# Altium schematic sheet EIT_IO.SchDoc
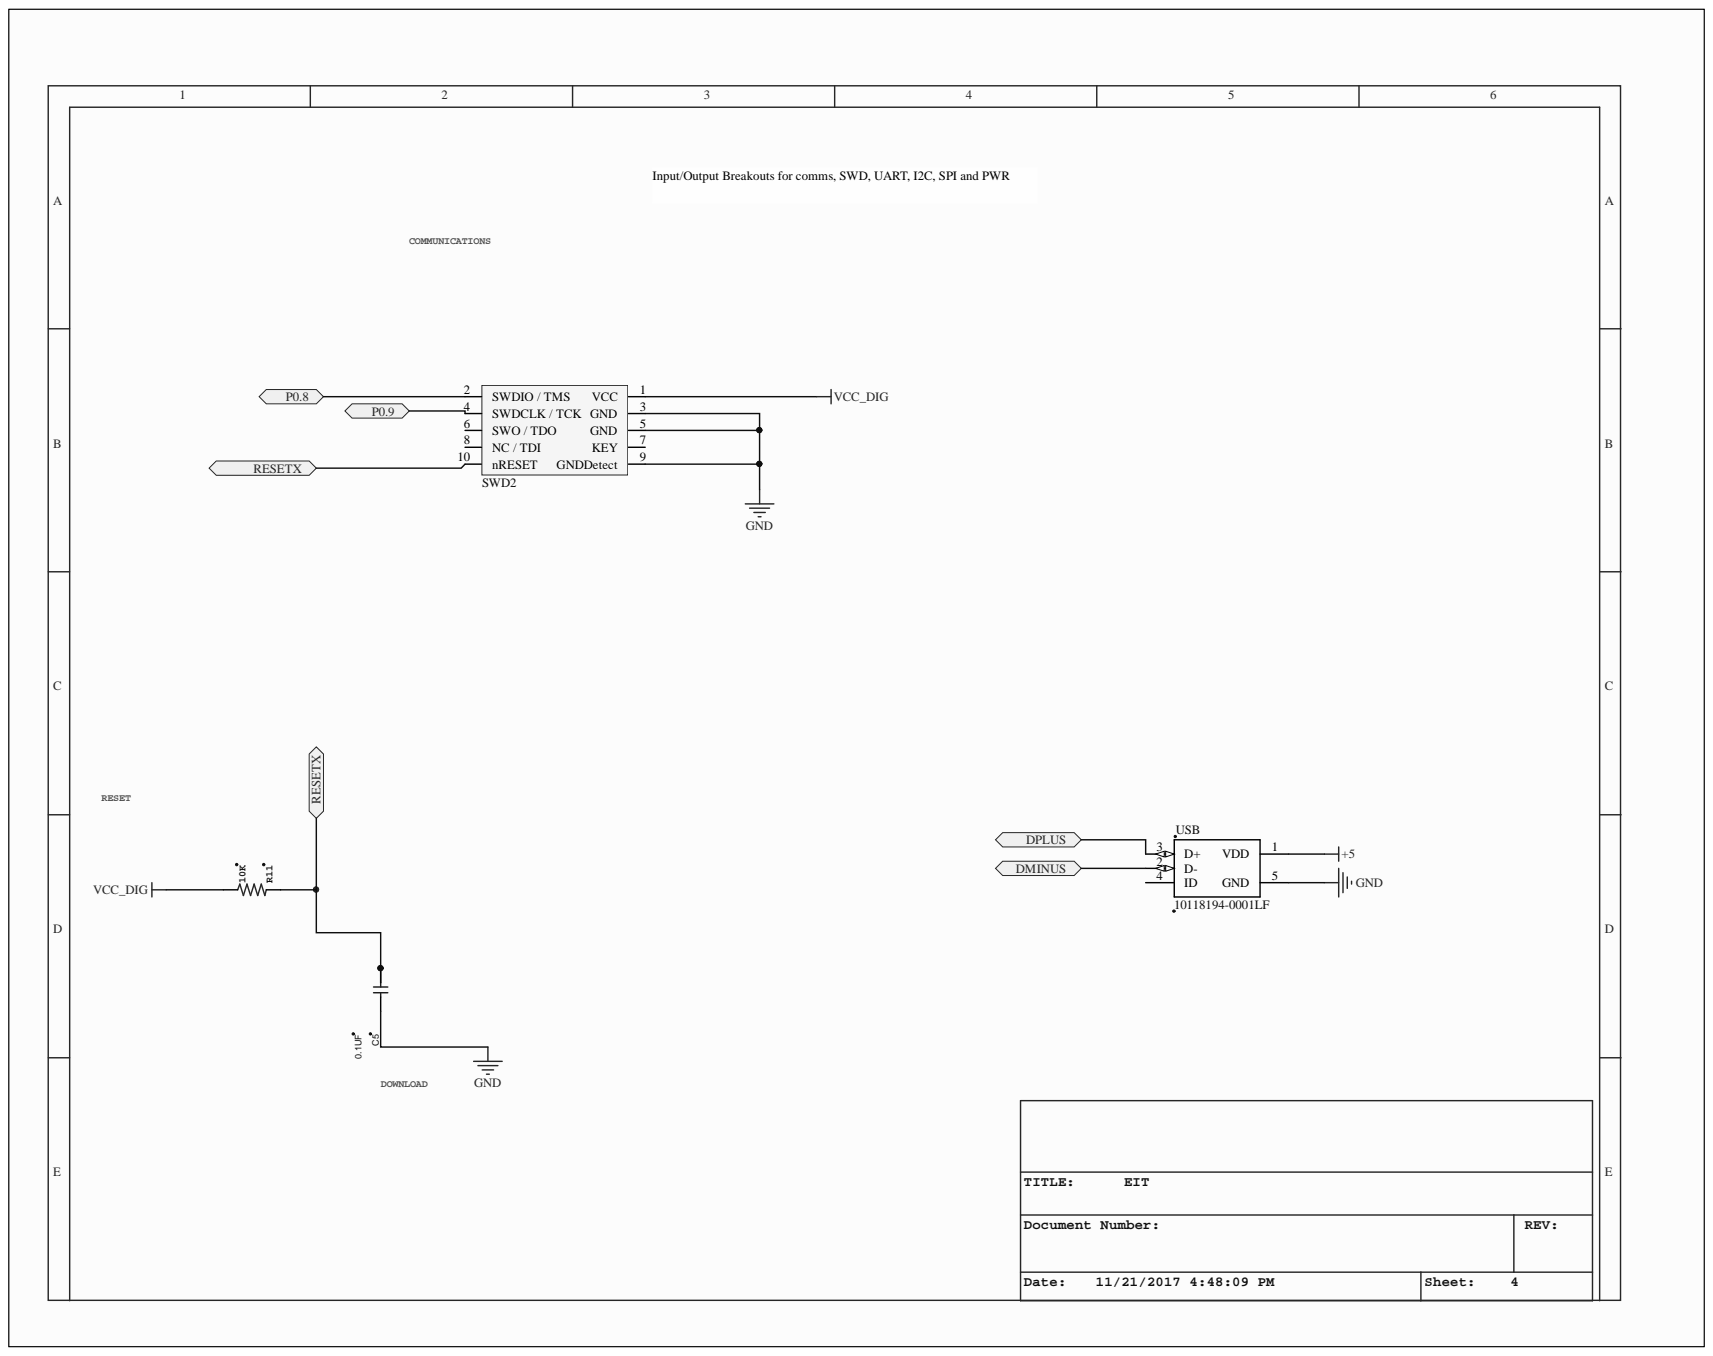
[diagram p0: EIT_IO.SchDoc]

## Parts
C5  0.1UF  [CAP 0402/1005]
R11  10K  [RES_1608X55]
SWD2  SWD Header THRU  [2X5-CONN_1.27MM]  (value not drawn)
USB  10118194-0001LF  [FRAMATOME_10118194-0001LF]

## Ports
DMINUS, DPLUS, P0.8, P0.9, RESETX

## Nets
+5: USB.1(VDD)
GND: C5.1 SWD2.3(GND) SWD2.5(GND) SWD2.9(GNDDetect) USB.5(GND)
VCC_DIG: R11.2 SWD2.1(VCC)
DMINUS: USB.2(D-) ; port DMINUS
DPLUS: USB.3(D+) ; port DPLUS
P0.8: SWD2.2 ; port P0.8
P0.9: SWD2.4 ; port P0.9
RESETX: C5.2 R11.1 SWD2.10(nRESET) ; port RESETX

Not connected: SWD2 6(SWO_/_TDO) 7(KEY) 8(NC_/_TDI); USB 4(ID)

## Library data of the parts
C5 = CAP_0402_0.1UF: CAP CER 0.1UF 4V X5R 0402 10% tolerance; Manufacturer 1: KEMET; Manufacturer Part Number 1: C0402C104K7PAC7867; Vendor: DIGIKEY; Component Kind: Standard; Supplier 1: Digi-Key; Supplier Part Number 1: 399-14871-1-ND; Value: 0.1UF; Library Name: EIT_lib.SchLib
R11 = RES_0603_10K: 10kOhm RES_0603; Manufacturer 1: VISHAY/DALE; Manufacturer Part Number 1: TNPW060310K0BEEA; PARTNO: MCR03ERTF5600; DeviceName: -0603(1608METRIC); DeviceSetName: RESISTOR-US; GateName_1: G$1; Component Kind: Standard; OC_NEWARK: 52K8621; Supplier 1: Digi-Key; Supplier Part Number 1: TNP10.0KAACT-ND; SymbolName_1: RESISTOR-US; Value: 10K; Library Name: EIT_lib.SchLib; LibraryName: BiomedicalImagingLibrary
SWD2 = 10 Pin Jtag Header_THRU: 10 Positions Header Connector 0.050" (1.27mm) Through Hole Gold; Manufacturer 1: CNC Tech; Manufacturer Part Number 1: 3220-10-0100-00; Component Kind: Standard; Supplier 1: Digi-Key; Supplier 2: Digi-Key; Supplier Part Number 2: 1175-1627-ND; Library Name: EIT_lib.SchLib
USB = 10118194-0001LF: CONN USB MICRO B RECPT SMT R/A; Manufacturer 1: Amphenol; Availability: Good; Component Kind: Standard; Manufacturer Part Number 1: 10118194-0001LF; Package: DIP-4 FCI; Price: 0.24 USD; Supplier 1: Digi-Key; Supplier Part Number 1: 609-4618-1-ND; Library Name: EIT_lib.SchLib
